# Altium schematic sheet OD2.SchDoc
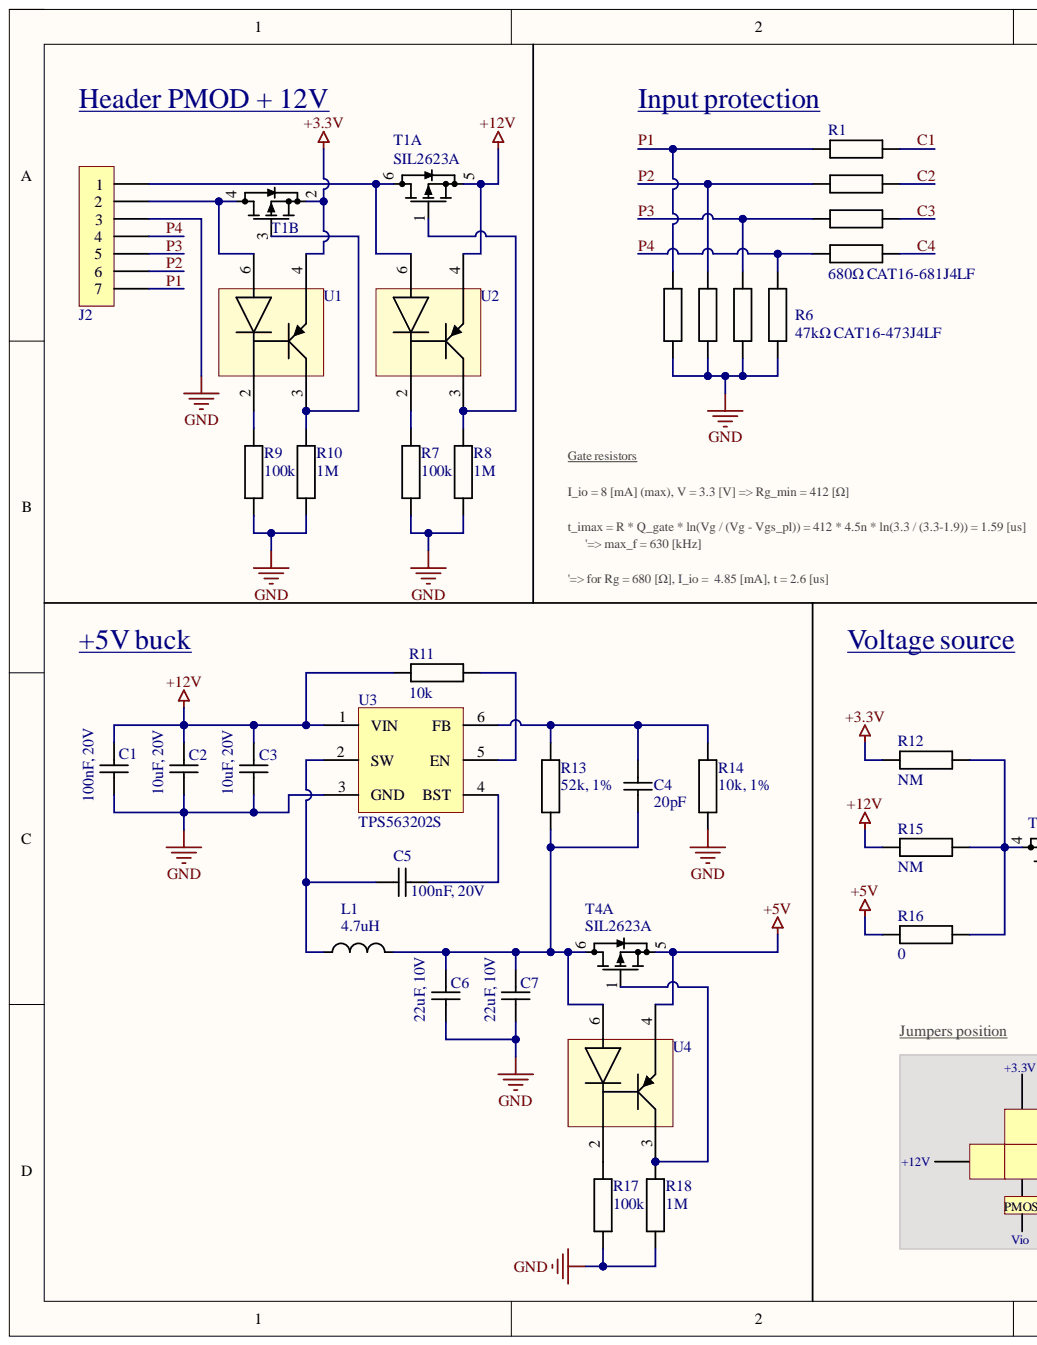
[diagram p0: OD2.SchDoc, left]
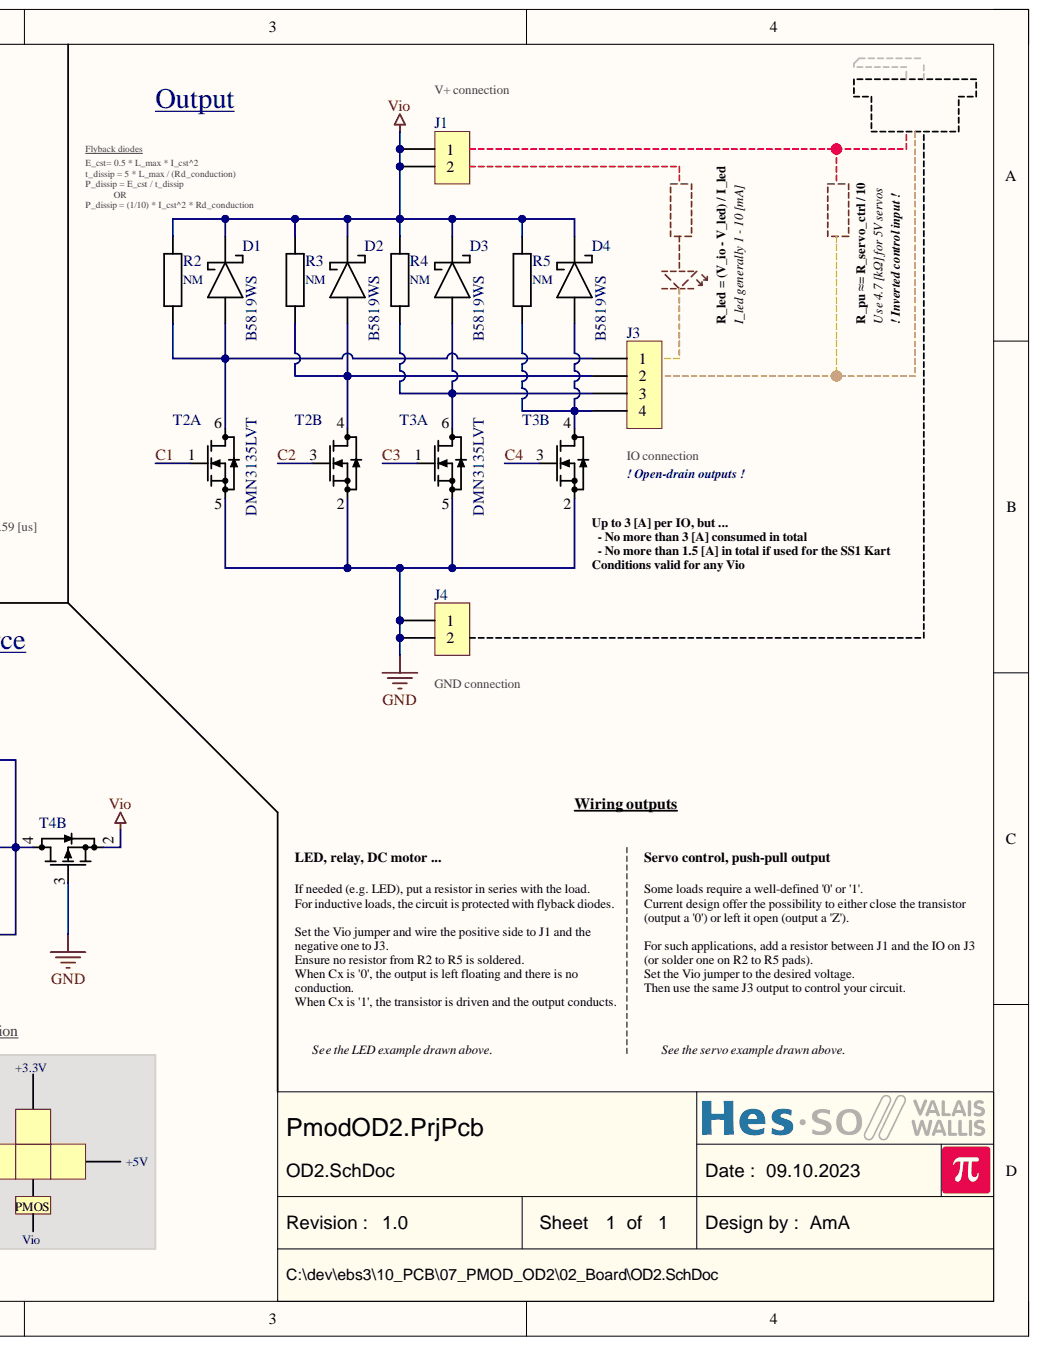
[diagram p1: OD2.SchDoc, right]

## Parts
C1  100nF, 20V  [CAPACITOR 1608 REFLOW]
C2  10uF, 20V  [CAPACITOR 1608 REFLOW]
C3  10uF, 20V  [CAPACITOR 1608 REFLOW]
C4  20pF  [CAPACITOR 1608 REFLOW]
C5  100nF, 20V  [CAPACITOR 1608 REFLOW]
C6  22uF, 10V  [CAPACITOR 1608 REFLOW]
C7  22uF, 10V  [CAPACITOR 1608 REFLOW]
D1  B5819WS  [DIODE SOD323 REFLOW]
D2  B5819WS  [DIODE SOD323 REFLOW]
D3  B5819WS  [DIODE SOD323 REFLOW]
D4  B5819WS  [DIODE SOD323 REFLOW]
J1  TB002-500-02BE  [ScrewHeader2 P5.0]  (value not drawn)
J2  (no value)  [PinHeader1x7 P2.54 Right Angle]
J3  Header4  [ScrewHeader4 P5.0]  (value not drawn)
J4  TB002-500-02BE  [ScrewHeader2 P5.0]  (value not drawn)
L1  LQH5BPN4R7NT0L  [LPS4018]  (value not drawn)
R1  680Ω CAT16-681J4LF  [ARRAY EXB38V]
R2  NM  [RESISTOR 2012 REFLOW]
R3  NM  [RESISTOR 2012 REFLOW]
R4  NM  [RESISTOR 2012 REFLOW]
R5  NM  [RESISTOR 2012 REFLOW]
R6  47kΩ CAT16-473J4LF  [ARRAY EXB38V]
R7  100k  [RESISTOR 1608 REFLOW]
R8  1M  [RESISTOR 1608 REFLOW]
R9  100k  [RESISTOR 1608 REFLOW]
R10  1M  [RESISTOR 1608 REFLOW]
R11  10k  [RESISTOR 1608 REFLOW]
R12  NM  [RESISTOR 1608 REFLOW]
R13  52k, 1%  [RESISTOR 1608 REFLOW]
R14  10k, 1%  [RESISTOR 1608 REFLOW]
R15  NM  [RESISTOR 1608 REFLOW]
R16  0  [RESISTOR 1608 REFLOW]
R17  100k  [RESISTOR 1608 REFLOW]
R18  1M  [RESISTOR 1608 REFLOW]
T1  SIL2623A  [SOT23-6 P0.95 C1.5x3.0 H1.3]  (parts A,B)
T2  DMN3135LVT  [SOT23-6 P0.95 C1.5x3.0 H1.3]  (parts A,B)
T3  DMN3135LVT  [SOT23-6 P0.95 C1.5x3.0 H1.3]  (parts A,B)
T4  SIL2623A  [SOT23-6 P0.95 C1.5x3.0 H1.3]  (parts A,B)
U1  DZDH0401DW  [SOT363 P0.65 C1.3x2.2 H1.0]  (value not drawn)
U2  DZDH0401DW  [SOT363 P0.65 C1.3x2.2 H1.0]  (value not drawn)
U3  TPS563202S  [SOT563 P0.5 C1.7x1.3 H0.6]
U4  DZDH0401DW  [SOT363 P0.65 C1.3x2.2 H1.0]  (value not drawn)

## Nets
+3.3V: R12.2 T1.2 U1.4
+5V: R16.1 T4.5 U4.4
+12V: C1.1 C2.2 C3.2 R11.2 R15.1 T1.5 U2.4 U3.1(VIN)
GND: C1.2 C2.1 C3.1 C6.1 C7.1 J2.3 J4.1 J4.2 R6.1 R6.3 R6.5 R6.7 R7.2 R8.1 R9.2 R10.1 R14.2 R17.1 R18.2 T2.2 T2.5 T3.2 T3.5 T4.3 U3.3(GND)
Vio: D1.1 D2.1 D3.1 D4.1 J1.1 J1.2 R2.2 R3.2 R4.2 R5.2 T4.2
C1: R1.2 T2.1
C2: R1.4 T2.3
C3: R1.6 T3.1
C4: R1.8 T3.3
P1: J2.7 R1.1 R6.2
P2: J2.6 R1.3 R6.4
P3: J2.5 R1.5 R6.6
P4: J2.4 R1.7 R6.8
NetC4_1: C4.1 C6.2 C7.2 L1.2 R13.1 T4.6 U4.6
NetC4_2: C4.2 R13.2 R14.1 U3.6(FB)
NetC5_1: C5.1 U3.4(BST)
NetC5_2: C5.2 L1.1 U3.2(SW)
NetD1_2: D1.2 J3.1 R2.1 T2.6
NetD2_2: D2.2 J3.2 R3.1 T2.4
NetD3_2: D3.2 J3.3 R4.1 T3.6
NetD4_2: D4.2 J3.4 R5.1 T3.4
NetJ2_1: J2.1 T1.6 U2.6
NetJ2_2: J2.2 T1.4 U1.6
NetR7_1: R7.1 U2.2
NetR8_2: R8.2 T1.1 U2.3
NetR9_1: R9.1 U1.2
NetR10_2: R10.2 T1.3 U1.3
NetR11_1: R11.1 U3.5(EN)
NetR12_1: R12.1 R15.2 R16.2 T4.4
NetR17_2: R17.2 U4.2
NetR18_1: R18.1 T4.1 U4.3

## Library data of the parts
C1-C7 = Capacitor: Component Kind: Standard; Description: Capacitor; Library Name: Capacitor.SchLib
  C1 C5: Value: 100nF, 20V
  C2 C3: Value: 10uF, 20V
  C4: Value: 20pF
  C6 C7: Value: 22uF, 10V
D1-D4 = DIODE SCHOTTKY: Diode Schottky generic 2 Leads; Component Kind: Standard; Library Name: Diode.SchLib; Value: B5819WS
J1 J4 = Header2: PCB terminal block; Component Kind: Standard; Library Name: Header.SchLib; value: TB002-500-02BE
J2 = PinHeader1x7: Plug connector; Component Kind: Standard; Library Name: PinHeader.SchLib
J3 = Header4: PCB terminal block; value: TB002-500-04BE; Component Kind: Standard; Library Name: Header.SchLib
L1 = Inductor: Component Kind: Standard; Description: Inductor; Library Name: Inductor.SchLib; Value: LQH5BPN4R7NT0L
R1 R6 = Array 4x Isolated: Component Kind: Standard; Description: Array 4x Isolated; Library Name: Resistor.SchLib
  R1: Value: 680Ω CAT16-681J4LF
  R6: Value: 47kΩ CAT16-473J4LF
R2-R5 R7-R18 = Resistor: Component Kind: Standard; Description: Resistor; Library Name: Resistor.SchLib
  R2-R5 R12 R15: Value: NM
  R7 R9 R17: Value: 100k
  R8 R10 R18: Value: 1M
  R11: Value: 10k
  R13: Value: 52k, 1%
  R14: Value: 10k, 1%
  R16: Value: 0
T1 T4 = MOS-P SOT23-6 G-S-G-D-S-D: Generic MOS-P dual; Component Kind: Standard; Library Name: Transistor.SchLib; Value: SIL2623A
T2 T3 = MOS-N SOT23-6 G-S-G-D-S-D: Generic MOS-N dual; Component Kind: Standard; Library Name: Transistor.SchLib; Value: DMN3135LVT
U1 U2 U4 = DZDH0401DW: IDEAL DIODE CONTROLLER; Component Kind: Standard; Library Name: IntegratedCircuit.SchLib; Value: DZDH0401DW
U3 = TPS563202S: 4.3-V to 17-V Input, 3-A ECO Mode Synchronous Buck Converter; Component Kind: Standard; Library Name: VoltageConverter.SchLib; Value: TPS563202S
